# Altium schematic sheet Ctrl4CurrentSensor.SchDoc
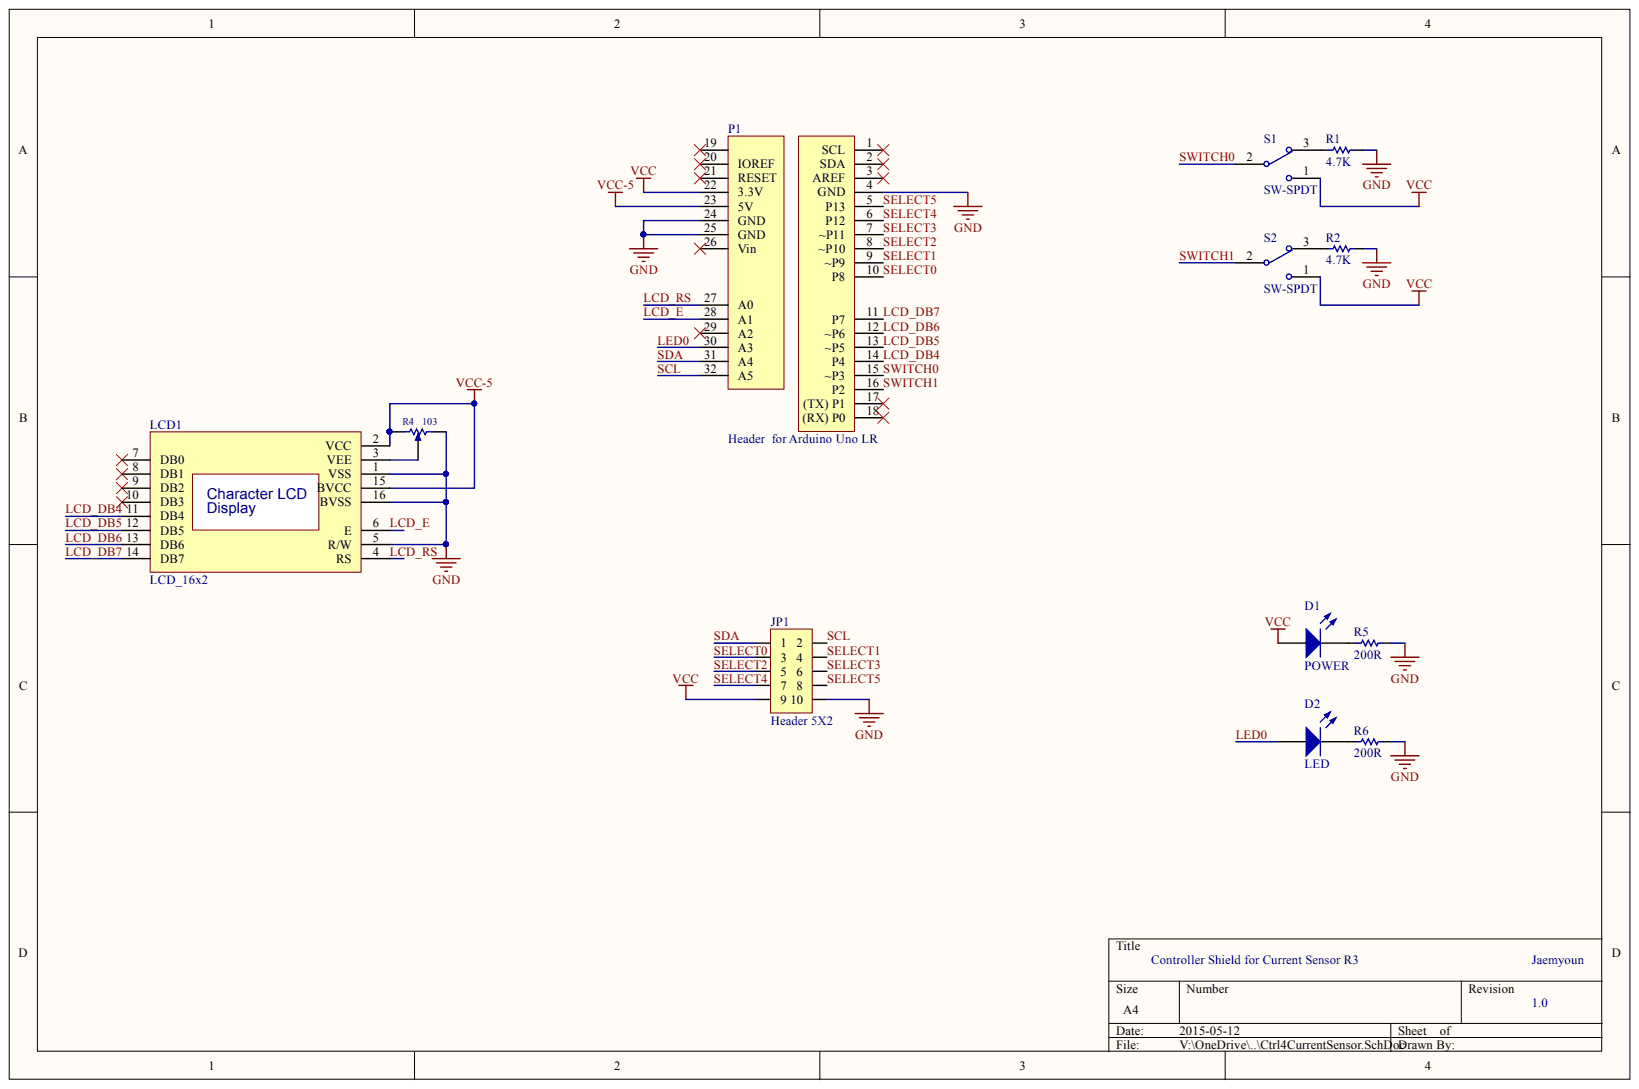
[diagram p0: Ctrl4CurrentSensor.SchDoc]

## Parts
D1  POWER  [LED-0603]
D2  LED  [LED-0603]
JP1  Header 5X2  [TPH03-5A]
LCD1  LCD_16x2  [LCD-16x2]
P1  Header  for Arduino Uno LR  [HDR for Arduino LR]
R1  4.7K  [R1608]
R2  4.7K  [R1608]
R4  103  [R-Trimmer]
R5  200R  [R1608]
R6  200R  [R1608]
S1  SW-SPDT  [SW-MicroSW]
S2  SW-SPDT  [SW-MicroSW]

## Nets
GND: JP1.10 LCD1.1(VSS) LCD1.5(R/W) LCD1.16(BVSS) P1.4(GND) P1.24(GND) P1.25(GND) R1.2 R2.2 R4.CW R5.2 R6.2
VCC: D1.A JP1.9 P1.22(3.3V) S1.1 S2.1
VCC-5: LCD1.2(VCC) LCD1.15(BVCC) P1.23(5V) R4.CCW
LCD_DB4: LCD1.11(DB4) P1.14(P4)
LCD_DB5: LCD1.12(DB5) P1.13(~P5)
LCD_DB6: LCD1.13(DB6) P1.12(~P6)
LCD_DB7: LCD1.14(DB7) P1.11(P7)
LCD_E: LCD1.6(E) P1.28(A1)
LCD_RS: LCD1.4(RS) P1.27(A0)
LED0: D2.A P1.30(A3)
SCL: JP1.2 P1.32(A5)
SDA: JP1.1 P1.31(A4)
SELECT0: JP1.3 P1.10(P8)
SELECT1: JP1.4 P1.9(~P9)
SELECT2: JP1.5 P1.8(~P10)
SELECT3: JP1.6 P1.7(~P11)
SELECT4: JP1.7 P1.6(P12)
SELECT5: JP1.8 P1.5(P13)
SWITCH0: P1.15(~P3) S1.2
SWITCH1: P1.16(P2) S2.2
NetD1_K: D1.K R5.1
NetD2_K: D2.K R6.1
NetLCD1_3: LCD1.3(VEE) R4.W
NetR1_1: R1.1 S1.3
NetR2_1: R2.1 S2.3

Not connected: LCD1 7(DB0) 8(DB1) 9(DB2) 10(DB3); P1 1(SCL) 2(SDA) 3(AREF) 17 18((RX)_P0) 19 20(IOREF) 21(RESET) 26(Vin) 29(A2)

## Library data of the parts
D1 = LED: Component Kind: Standard; Description: LED; LatestRevisionDate: 08-Jul-2005; LatestRevisionNote: Mech Layer 1 removed.; Library Name: JLib.IntLib; PackageDescription: LED; 2 Leads; PackageReference: LED-0; Published: 8-Jun-2000; Publisher: Altium Limited
JP1 = Header 5X2: CONNECTOR; Component Kind: Standard; Library Name: JLib.IntLib
P1 = Header for Arduino Uno LR: Arduino Uno, L & R, Header, 32-Pin; Component Kind: Standard; LatestRevisionDate: 17-Jul-2002; LatestRevisionNote: Re-released for DXP Platform.; Library Name: JLib.SchLib; Publisher: Altium Limited
R1 R2 R5 R6 = Res: Resistor; Value: 1K; Component Kind: Standard; LatestRevisionDate: 17-Jul-2002; LatestRevisionNote: Re-released for DXP Platform.; Library Name: JLib.IntLib; PackageDescription: Axial Device, Thru-Hole; 2 Leads; 0.3 in Pin Spacing; PackageReference: AXIAL-0.3; Published: 8-Jun-2000; Publisher: Altium Limited
R4 = R-trimmer: Square Trimming Potentiometer; AEMS Code: 1400226; Component Kind: Standard; Library Name: Schlib1.SchLib
S1 S2 = SW-SPDT: SPDT Subminiature Toggle Switch, Right Angle Mounting, Vertical Actuation; Component Kind: Standard; ComponentLink1Description: Manufacturer Link; ComponentLink1URL: http://www.apem.com; DatasheetVersion: 05-Dec-2000; LatestRevisionDate: 17-Dec-2003; LatestRevisionNote: Schematic revised, PCB Footprint replaced.; Library Name: JLib.IntLib; PackageDescription: Switch; 4 Leads; PackageReference: TL36WW15050; Published: 24-Mar-1999; Publisher: Altium Limited
D2 = LED: Component Kind: Standard; Description: LED; LatestRevisionDate: 08-Jul-2005; LatestRevisionNote: Mech Layer 1 removed.; Library Name: JLib.IntLib; PackageDescription: LED; 2 Leads; PackageReference: LED-0; Published: 8-Jun-2000; Publisher: Altium Limited
LCD1 = LCD_16x2: Component Kind: Standard; Library Name: JLib.IntLib
